# Altium schematic sheet connector_board_v2.SchDoc(connector_board_v2)
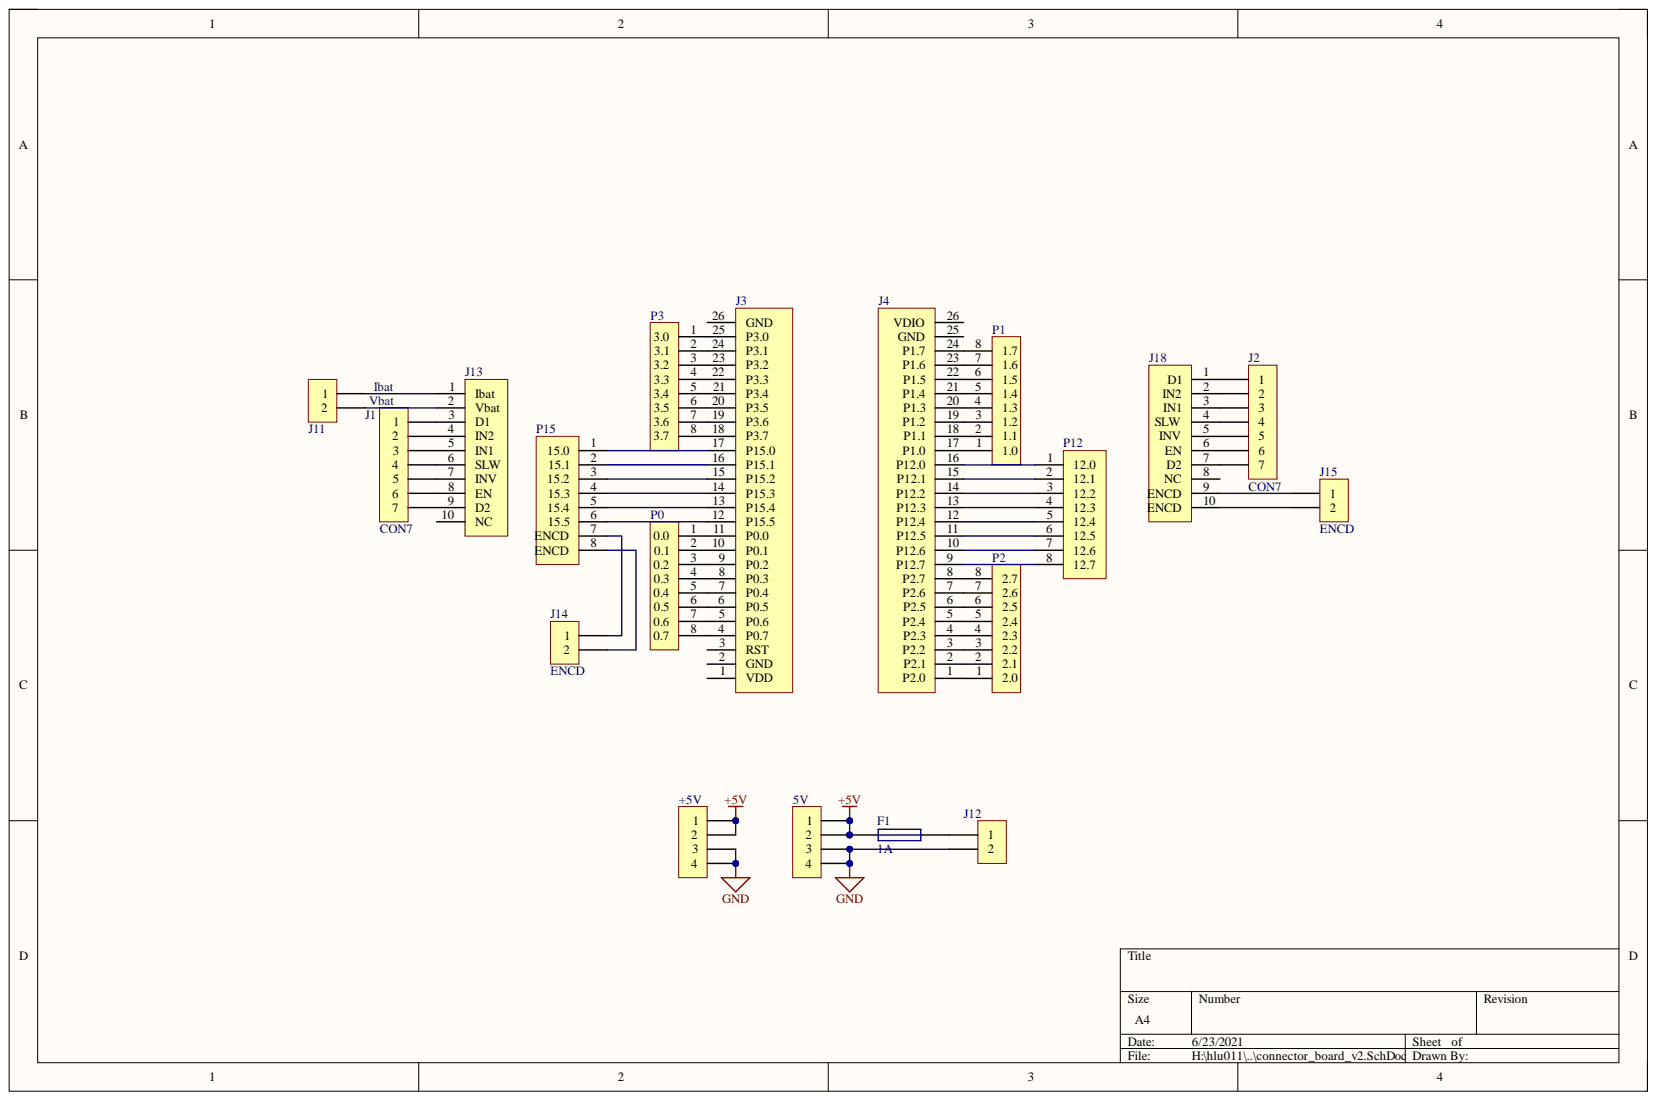
[diagram p0: connector_board_v2.SchDoc]

## Parts
5V  5V  [IDC4]
+5V  5V  [IDC4]
F1  1A  [LittelFuse154]
J1  CON7  [SIP7]
J2  CON7  [SIP7]
J3  CY8CKIT-059_left  [SIP26]  (value not drawn)
J4  CY8CKIT-059_right  [SIP26]  (value not drawn)
J11  Battery  [sip2]  (value not drawn)
J12  5V  [sip2]  (value not drawn)
J13  CON10  (value not drawn)
J14  ENCD  [sip2]
J15  ENCD  [sip2]
J18  CON10  [IDC10]  (value not drawn)
P0  P0  [idc8]
P1  P1  [idc8]
P2  P2  [idc8]
P3  P3  [idc8]
P12  P12
P15  P15

## Nets
+5V: 5V.1 5V.2 +5V.1 +5V.2 F1.1
GND: 5V.3 5V.4 +5V.3 +5V.4 J12.2
NetF1_2: F1.2 J12.1
NetJ1_1: J1.1 J13.3(D1)
NetJ1_2: J1.2 J13.4(IN2)
NetJ1_3: J1.3 J13.5(IN1)
NetJ1_4: J1.4 J13.6(SLW)
NetJ1_5: J1.5 J13.7(INV)
NetJ1_6: J1.6 J13.8(EN)
NetJ1_7: J1.7 J13.9(D2)
NetJ2_1: J2.1 J18.1(D1)
NetJ2_2: J2.2 J18.2(IN2)
NetJ2_3: J2.3 J18.3(IN1)
NetJ2_4: J2.4 J18.4(SLW)
NetJ2_5: J2.5 J18.5(INV)
NetJ2_6: J2.6 J18.6(EN)
NetJ2_7: J2.7 J18.7(D2)
NetJ3_4: J3.4(P0.7) P0.8(0.7)
NetJ3_5: J3.5(P0.6) P0.7(0.6)
NetJ3_6: J3.6(P0.5) P0.6(0.5)
NetJ3_7: J3.7(P0.4) P0.5(0.4)
NetJ3_8: J3.8(P0.3) P0.4(0.3)
NetJ3_9: J3.9(P0.2) P0.3(0.2)
NetJ3_10: J3.10(P0.1) P0.2(0.1)
NetJ3_11: J3.11(P0.0) P0.1(0.0)
NetJ3_12: J3.12(P15.5) P15.6(15.5)
NetJ3_13: J3.13(P15.4) P15.5(15.4)
NetJ3_14: J3.14(P15.3) P15.4(15.3)
NetJ3_15: J3.15(P15.2) P15.3(15.2)
NetJ3_16: J3.16(P15.1) P15.2(15.1)
NetJ3_17: J3.17(P15.0) P15.1(15.0)
NetJ3_18: J3.18(P3.7) P3.8(3.7)
NetJ3_19: J3.19(P3.6) P3.7(3.6)
NetJ3_20: J3.20(P3.5) P3.6(3.5)
NetJ3_21: J3.21(P3.4) P3.5(3.4)
NetJ3_22: J3.22(P3.3) P3.4(3.3)
NetJ3_23: J3.23(P3.2) P3.3(3.2)
NetJ3_24: J3.24(P3.1) P3.2(3.1)
NetJ3_25: J3.25(P3.0) P3.1(3.0)
NetJ4_1: J4.1(P2.0) P2.1(2.0)
NetJ4_2: J4.2(P2.1) P2.2(2.1)
NetJ4_3: J4.3(P2.2) P2.3(2.2)
NetJ4_4: J4.4(P2.3) P2.4(2.3)
NetJ4_5: J4.5(P2.4) P2.5(2.4)
NetJ4_6: J4.6(P2.5) P2.6(2.5)
NetJ4_7: J4.7(P2.6) P2.7(2.6)
NetJ4_8: J4.8(P2.7) P2.8(2.7)
NetJ4_9: J4.9(P12.7) P12.8(12.7)
NetJ4_10: J4.10(P12.6) P12.7(12.6)
NetJ4_11: J4.11(P12.5) P12.6(12.5)
NetJ4_12: J4.12(P12.4) P12.5(12.4)
NetJ4_13: J4.13(P12.3) P12.4(12.3)
NetJ4_14: J4.14(P12.2) P12.3(12.2)
NetJ4_15: J4.15(P12.1) P12.2(12.1)
NetJ4_16: J4.16(P12.0) P12.1(12.0)
NetJ4_17: J4.17(P1.0) P1.1(1.0)
NetJ4_18: J4.18(P1.1) P1.2(1.1)
NetJ4_19: J4.19(P1.2) P1.3(1.2)
NetJ4_20: J4.20(P1.3) P1.4(1.3)
NetJ4_21: J4.21(P1.4) P1.5(1.4)
NetJ4_22: J4.22(P1.5) P1.6(1.5)
NetJ4_23: J4.23(P1.6) P1.7(1.6)
NetJ4_24: J4.24(P1.7) P1.8(1.7)
NetJ11_1: J11.1 J13.1(Ibat)
NetJ11_2: J11.2 J13.2(Vbat)
NetJ14_1: J14.1 P15.7(ENCD)
NetJ14_2: J14.2 P15.8(ENCD)
NetJ15_1: J15.1 J18.9(ENCD)
NetJ15_2: J15.2 J18.10(ENCD)

Not connected: J3 1(VDD) 2(GND) 3(RST) 26(GND); J4 25(GND) 26(VDIO); J13 10(NC); J18 8(NC)

## Library data of the parts
5V +5V = CON4: Connector; Component Kind: Standard; Library Name: Miscellaneous Devices.SCHLIB
F1 = FUSE1: Fuse; Component Kind: Standard; Library Name: Miscellaneous Devices.SCHLIB
J1 J2 = CON7: Connector; Component Kind: Standard; Library Name: Miscellaneous Devices.SCHLIB
J3 = CY8CKIT-059_left: CY8CKIT-059 5LP; Component Kind: Standard; Library Name: MySCH.lib
J4 = CY8CKIT-059_right: CY8CKIT-059 5LP; Component Kind: Standard; Library Name: MySCH.lib
J11 J12 J14 J15 = CON2: Connector; Component Kind: Standard; Library Name: Miscellaneous Devices.SCHLIB
J13 J18 = CON10: Connector; Component Kind: Standard; Library Name: Miscellaneous Devices.SCHLIB
P0-P3 = CON8: Connector; Component Kind: Standard; Library Name: Miscellaneous Devices.SCHLIB
P12 P15 = CON8_1: Connector; Component Kind: Standard; Library Name: Miscellaneous Devices.SCHLIB
